# Altium schematic sheet Main.SchDoc(Main)
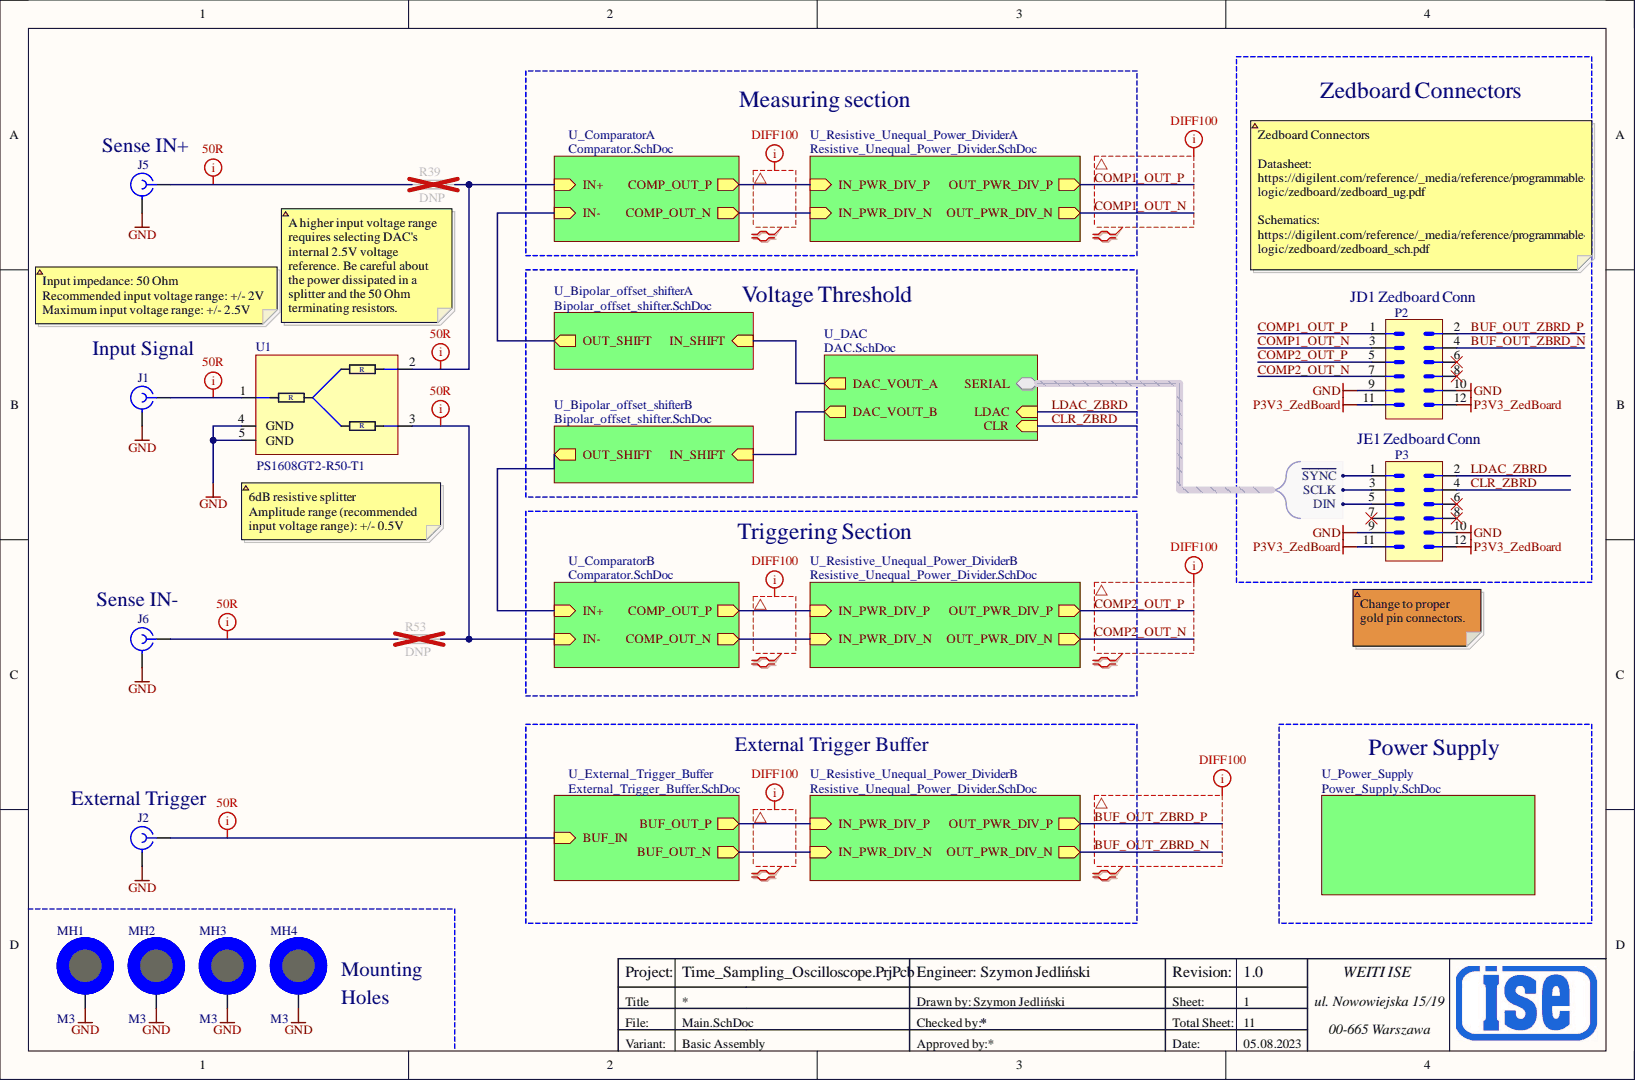
[diagram p0: Main.SchDoc]

## Parts
J1  CONSMA020.062-G  (value not drawn)
J2  CONSMA020.062-G  (value not drawn)
J5  CONSMA020.062-G  (value not drawn)
J6  CONSMA020.062-G  (value not drawn)
MH1  M3  [MH-M3]  (no BOM)
MH2  M3  [MH-M3]  (no BOM)
MH3  M3  [MH-M3]  (no BOM)
MH4  M3  [MH-M3]  (no BOM)
P2  DF11-12DP-2DSA  [DF11-12DP-2DSA]  (value not drawn)
P3  DF11-12DP-2DSA  [DF11-12DP-2DSA]  (value not drawn)
R39  DNP  [RESC0402X40N]
R53  DNP  [RESC0402X40N]
U1  PS1608GT2-R50-T1

## Nets
GND: J1.2 J2.2 J5.2 J6.2 MH1.MH MH2.MH MH3.MH MH4.MH P2.9 P2.10 P3.9 P3.10 U1.4(GND) U1.5(GND)
P3V3_ZedBoard: P2.11 P2.12 P3.11 P3.12
BUF_OUT_ZBRD_N: P2.4
BUF_OUT_ZBRD_P: P2.2
CLR_ZBRD: P3.4
COMP1_OUT_N: P2.3
COMP1_OUT_P: P2.1
COMP2_OUT_N: P2.7
COMP2_OUT_P: P2.5
LDAC_ZBRD: P3.2
NetJ1_1: J1.1 U1.1
NetJ2_1: J2.1
NetJ5_1: J5.1 R39.2
NetJ6_1: J6.1 R53.1
NetP2_6: P2.6
NetP2_8: P2.8
NetP3_1: P3.1
NetP3_3: P3.3
NetP3_5: P3.5
NetP3_6: P3.6
NetP3_7: P3.7
NetP3_8: P3.8
NetR39_1: R39.1 U1.2
NetR53_2: R53.2 U1.3
N00001:
N00002:
N00003:
N00004:
N00005:
N00006:
N00007:
N00008:
N00009:
N00010:

## Library data of the parts
J1 J2 J5 J6 = CONSMA020.062-G: Coaxial Connectors SMA, Edge Mount, Female Receptacle, 0.062" PCB Mount, Gold; Manufacturer 1 Component Height: 7.92mm; Database Table Name: Connectors_SMD; Component Kind: Standard; DesignItemId: CONSMA020.062-G; HelpURL: https://www.mouser.pl/datasheet/2/418/9/ENG_DS_L9000279_01_A-3238972.pdf; Library Name: Connectors.DbLib; Manufacturer Part Number: CONSMA020.062-G; SMD: Yes
MH1-MH4 = MH-M3: Mounting hole, M3, no metalization; Database Table Name: PCB_Features; DesignItemId: MH-M3; Family: M3; Library Name: Mechanical_and_PCB_Features.DbLib; Part Description: Mounting hole, M3, no metalization
P2 P3 = DF11-12DP-2DSA(08): Socket wire-board, male, Pitch 2mm, PIN: 12; Manufacturer 1 Component Height: 6.6mm; Database Table Name: Connectors_THD; Component Kind: Standard; DesignItemId: DF11-12DP-2DSA(08); HelpURL: https://pl.mouser.com/datasheet/2/185/DF11_CL0543_0521_2_08_2d-1611425.pdf; Library Name: Connectors.DbLib; Manufacturer Part Number: DF11-12DP-2DSA; SMD: No
R39 R53 = R0402_DNP: General Purpose Thick Film Chip Resistor; Manufacturer 1 Component Height: 0.4mm; Database Table Name: Resistors_SMD; Voltage: 50V; Component Kind: Standard; DesignItemId: R0402_DNP; HelpURL: https://www.vishay.com/docs/20035/dcrcwe3.pdf; Library Name: Resistors.DbLib; SMD: Yes; Value: DNP
U1 = PS1608GT2-R50-T1: Signal Conditioning 50 ohm 2 terminal Power Splitter; Manufacturer 1 Component Height: 0.5mm; Database Table Name: Resistors_SMD; Component Kind: Standard; DesignItemId: PS1608GT2-R50-T1; HelpURL: https://www.mouser.pl/datasheet/2/392/n_catalog_partition31_en-1660522.pdf; Library Name: Resistors.DbLib; Manufacturer Part Number: PS1608GT2-R50-T1; SMD: Yes; Value: PS1608GT2-R50-T1
